# Altium schematic sheet [06] USB_C.SchDoc("[06] USB_C")
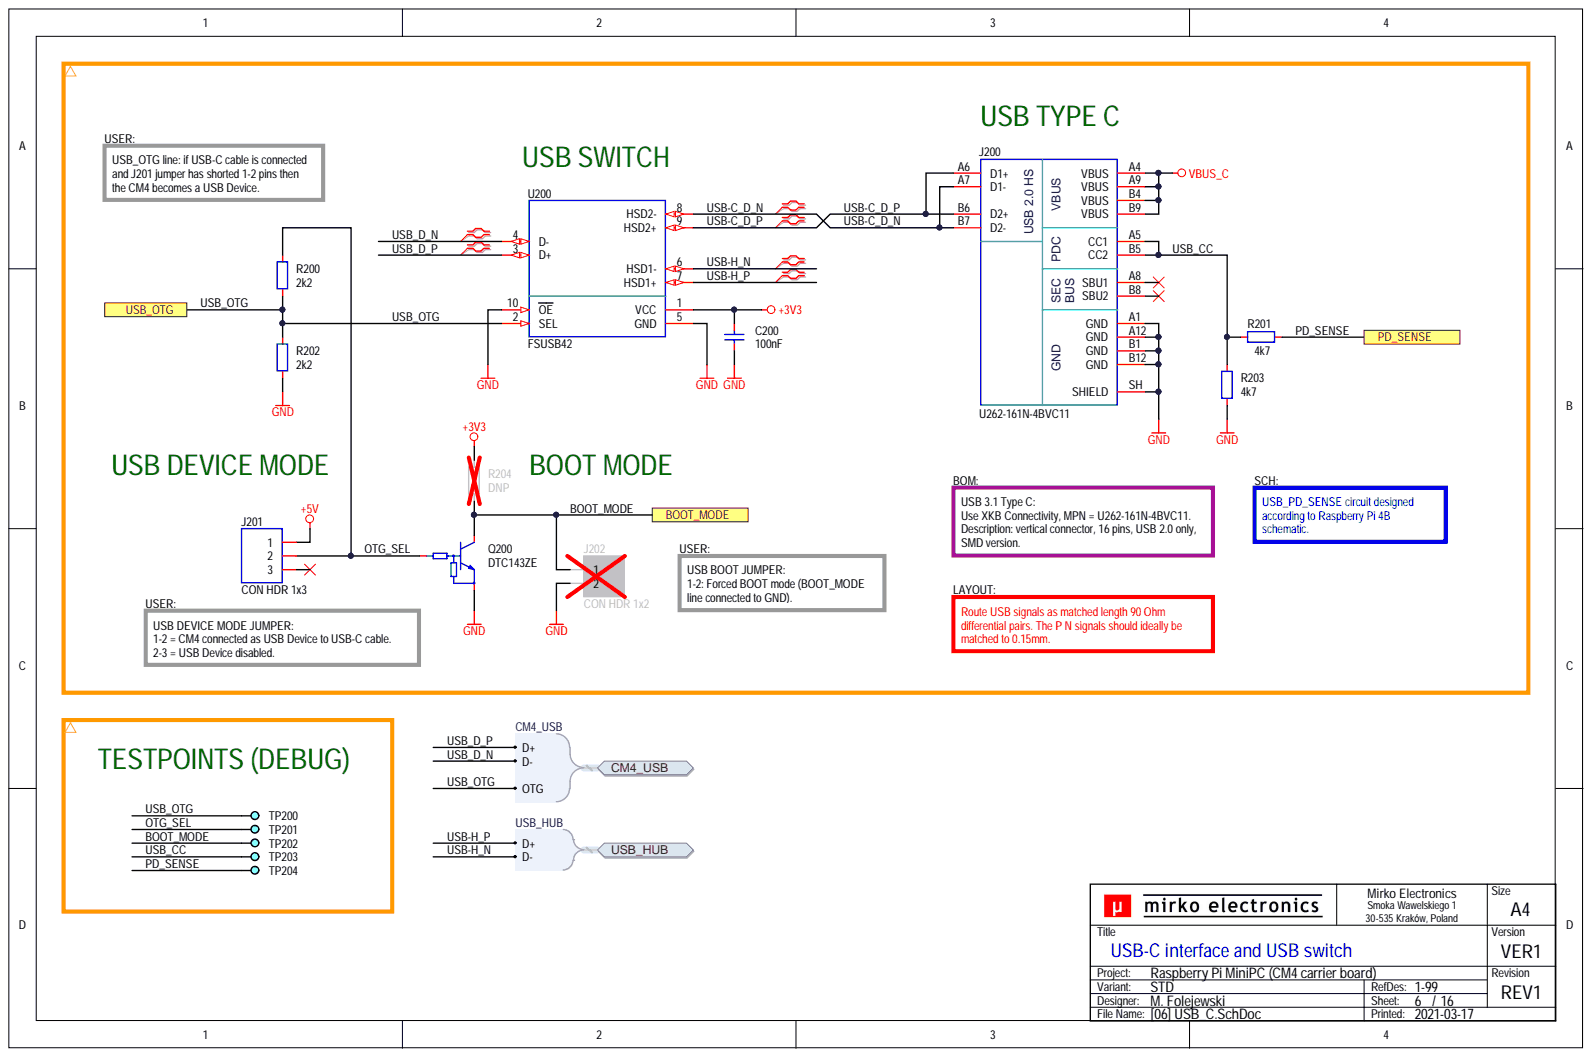
[diagram p0: [06] USB_C.SchDoc]

## Parts
C200  100nF  [CAP_0603_R]
J200  U262-161N-4BVC11  [CON_XKB_U262-161N-4BVC11]
J201  CON HDR 1x3  [CON_HDR_P254_1X03_STR]
J202  CON HDR 1x2  [CON_HDR_P254_1X02_STR]
Q200  DTC143ZE  [PKG_SOT523_SC75_SOT416_P100_R]
R200  2k2  [RES_0603_R]
R201  4k7  [RES_0603_R]
R202  2k2  [RES_0603_R]
R203  4k7  [RES_0603_R]
R204  DNP  [RES_0603_R]
TP200  DEBUG  [PCB_TP_DBG_50]  (value not drawn)  (no BOM)
TP201  DEBUG  [PCB_TP_DBG_50]  (value not drawn)  (no BOM)
TP202  DEBUG  [PCB_TP_DBG_50]  (value not drawn)  (no BOM)
TP203  DEBUG  [PCB_TP_DBG_50]  (value not drawn)  (no BOM)
TP204  DEBUG  [PCB_TP_DBG_50]  (value not drawn)  (no BOM)
U200  FSUSB42  [ICC_MSOP10_300X300H110_P50_R]

## Ports
BOOT_MODE, CM4_USB, CM4_USB.D+, CM4_USB.D-, CM4_USB.OTG, PD_SENSE, USB_HUB, USB_HUB.D+, USB_HUB.D-, USB_OTG

## Nets
+3V3: C200.2 R204.2 U200.1(VCC)
+5V: J201.1
GND: C200.1 J200.A1(GND) J200.A12(GND) J200.B1(GND) J200.B12(GND) J200.SH(SHIELD) J202.2 Q200.2 R202.1 R203.2 U200.5(GND) U200.10(OE)
VBUS_C: J200.A4(VBUS) J200.A9(VBUS) J200.B4(VBUS) J200.B9(VBUS)
BOOT_MODE: J202.1 Q200.3 R204.1 TP202.1 ; port BOOT_MODE
OTG_SEL: J201.2 Q200.1 R200.2 TP201.1
PD_SENSE: R201.1 TP204.1 ; port PD_SENSE
USB-C_D_N: J200.A7(D1-) J200.B7(D2-) U200.8(HSD2-)
USB-C_D_P: J200.A6(D1+) J200.B6(D2+) U200.9(HSD2+)
USB-H_N: U200.6(HSD1-) ; port USB_HUB
USB-H_P: U200.7(HSD1+) ; port USB_HUB
USB_CC: J200.A5(CC1) J200.B5(CC2) R201.2 R203.1 TP203.1
USB_D_N: U200.4(D-) ; port CM4_USB
USB_D_P: U200.3(D+) ; port CM4_USB
USB_OTG: R200.1 R202.2 TP200.1 U200.2(SEL) ; port CM4_USB, port USB_OTG

Not connected: J200 A8(SBU1) B8(SBU2); J201 3

## Library data of the parts
C200 = CAP-0008: Capacitor; MLCC; 100nF; TBD; TBD; TBD; TBD; SMD; 0603 (1608);; Database Table Name: CAPACITOR$; Voltage Rating: TBD; Value: 100nF; Tolerance: TBD; ROHS Status: TBD; Packaging Type: TBD; Package (Case): 0603 (1608); Operating Temperature: TBD; MPN: TBD; Mounting Type: SMD; Manufacturer: TBD; ID: CAP-0008; Features: TBD; Dielectric: TBD; Component Type: Capacitor; Component Subtype: MLCC; Component Kind: Standard; Component Height: TBD; Component Body: TBD; Capacitance: 100nF; Library Name: MirFol_db_local.DbLib
J200 = CON_USB_TYPE_C_16P: Connector; USB 3.1 Type C; Receptacle; 24-pin; USB 2.0 Only;; Manufacturer: XKB Connectivity; Value: U262-161N-4BVC11; MPN: U262-161N-4BVC11; Component Kind: Standard; Library Name: MirFol_lib.SchLib
J201 = CON_1X03_COL: Connector; 1 Row;; Value: CON HDR 1x3; Component Kind: Standard; Library Name: MirFol_lib.SchLib
J202 = CON-0020: Connector; Pin Header; 1 x 2 pins; 2.54mm; Male; TBD; TBD; THT; THT;; MPN: GENERIC; Database Table Name: CONNECTOR$; Value: CON HDR 1x2; ROHS Status: TBD; Pitch: 2.54mm; Pin Count: 2; Packaging Type: TBD; Package (Case): THT; Orientation: TBD; Operating Temperature: TBD; Mounting Type: THT; Manufacturer: GENERIC; ID: CON-0020; Features: TBD; Contact Rating: TBD; Contact Plating: TBD; Contact Gender: Male; Configuration: 1 x 2 pins; Component Type: Connector; Component Subtype: Pin Header; Component Kind: Standard; Component Height: TBD; Component Body: TBD; Library Name: MirFol_db_local.DbLib
Q200 = TRA_BJT_NPN_DIG_BEC: Transistor; BJT; NPN; Digital;; Value: DTC143ZE; Component Kind: Standard; Library Name: MirFol_lib.SchLib
R200 R202 = RES-0033: Resistor; Thick Film; 2k2; 1%; 100mW; 100PPM; 75V; Standard; SMD; 0603 (1608);; MPN: TBD; Manufacturer: Yageo Corporation; Packaging Type: Tape & Reel; Database Table Name: RESISTOR$; Component Body: 1.60 x 0.80mm; Voltage Rating: 75V; Value: 2k2; Tolerance: 1%; TCR: 100PPM; ROHS Status: RoHS Compliant; Resistance: 2k2; Power Rating: 100mW; Package (Case): 0603 (1608); Operating Temperature: -55°C to +155°C; Mounting Type: SMD; ID: RES-0033; Features: Standard; Component Type: Resistor; Component Subtype: Thick Film; Component Kind: Standard; Component Height: 0.45mm; Library Name: MirFol_db_local.DbLib
R201 R203 = RES-0043: Resistor; Thick Film; 4k7; 1%; 100mW; 100PPM; 75V; Standard; SMD; 0603 (1608);; MPN: TBD; Manufacturer: Yageo Corporation; Packaging Type: Tape & Reel; Database Table Name: RESISTOR$; Component Body: 1.60 x 0.80mm; Voltage Rating: 75V; Value: 4k7; Tolerance: 1%; TCR: 100PPM; ROHS Status: RoHS Compliant; Resistance: 4k7; Power Rating: 100mW; Package (Case): 0603 (1608); Operating Temperature: -55°C to +155°C; Mounting Type: SMD; ID: RES-0043; Features: Standard; Component Type: Resistor; Component Subtype: Thick Film; Component Kind: Standard; Component Height: 0.45mm; Library Name: MirFol_db_local.DbLib
R204 = RES-0051: Resistor; Thick Film; DNP; TBD; TBD; TBD; TBD; DO NOT PLACE; SMD; 0603 (1608);; Database Table Name: RESISTOR$; Component Body: 1.60 x 0.80mm; Voltage Rating: TBD; Value: DNP; Tolerance: TBD; TCR: TBD; ROHS Status: TBD; Resistance: DNP; Power Rating: TBD; Packaging Type: TBD; Package (Case): 0603 (1608); Operating Temperature: TBD; MPN: TBD; Mounting Type: SMD; Manufacturer: TBD; ID: RES-0051; Features: DO NOT PLACE; Component Type: Resistor; Component Subtype: Thick Film; Component Kind: Standard; Component Height: TBD; Library Name: MirFol_db_local.DbLib
TP200-TP204 = PCB_TP_DBG: PCB Marking; Test Point (Debug);; Value: DEBUG; Library Name: MirFol_lib.SchLib
U200 = ICC-0013: IC; USB Switch; TBD TBD; SMD; MSOP-10;; MPN: FSUSB42MUX; Manufacturer: ON Semiconductor; Pin Pitch: 0.50 mm; Database Table Name: IC (MISC)$; Value: FSUSB42; ROHS Status: TBD; Power Supply: TBD; Pin Count: 10; Packaging Type: TBD; Package (Case): MSOP-10; Operating Temperature: TBD; Mounting Type: SMD; ID: ICC-0013; Features: TBD; Component Type: IC; Component Subtype: USB Switch; Component Kind: Standard; Component Height: TBD; Component Body: TBD; Library Name: MirFol_db_local.DbLib
